# Altium schematic sheet HDMI.SchDoc(HDMI)
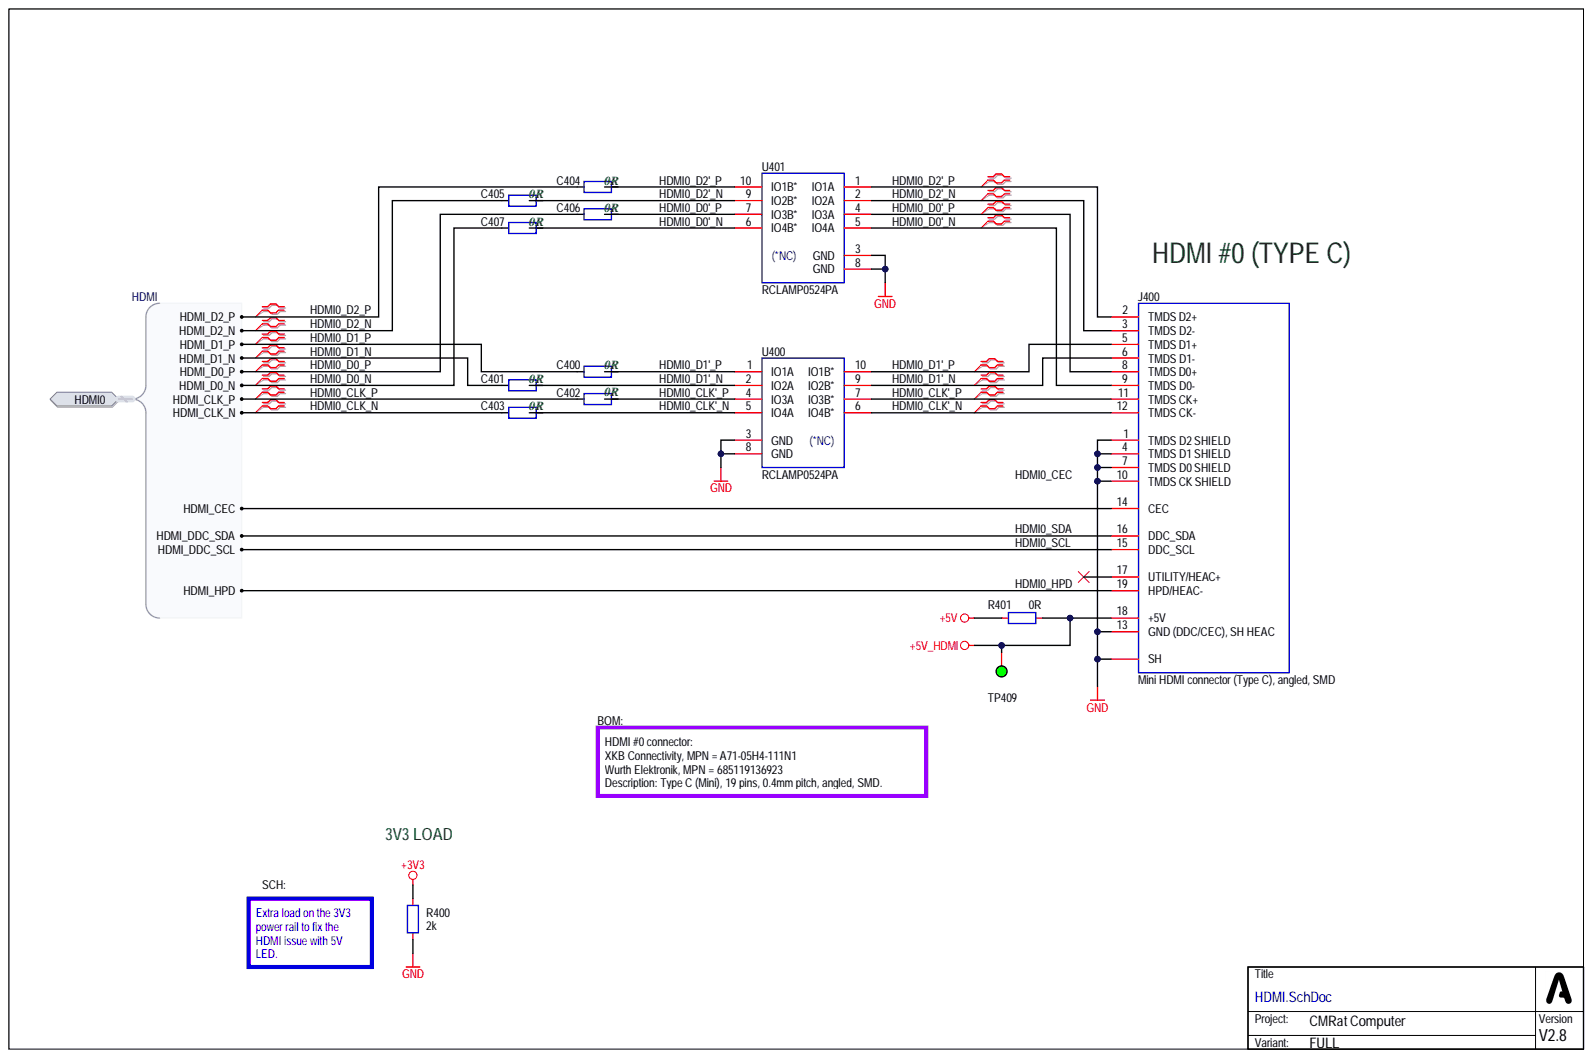
[diagram p0: HDMI.SchDoc]

## Parts
C400  0R  [RES_0402_R]
C400
C401  0R  [RES_0402_R]
C401
C402  0R  [RES_0402_R]
C402
C403  0R  [RES_0402_R]
C403
C404  0R  [RES_0402_R]
C404
C405  0R  [RES_0402_R]
C405
C406  0R  [RES_0402_R]
C406
C407  0R  [RES_0402_R]
C407
J400  Mini HDMI connector (Type C), angled, SMD  Mounting Type: SMD  [CON_XKB_A71-05H4-1X1N1]
R400  2k  [RES_0603_R]
R401  0R  [RES_0603_R]
TB400  =Value  (no BOM)
TP409  ICT  [PCB_TP_ICT_100]  (value not drawn)  (no BOM)
U400  RCLAMP0524PA  [ICC_SLP2510P8_250X100X60_P50_R]
U401  RCLAMP0524PA  [ICC_SLP2510P8_250X100X60_P50_R]

## Ports
HDMI0, HDMI0.HDMI_CEC, HDMI0.HDMI_CLK_N, HDMI0.HDMI_CLK_P, HDMI0.HDMI_D0_N, HDMI0.HDMI_D0_P, HDMI0.HDMI_D1_N, HDMI0.HDMI_D1_P, HDMI0.HDMI_D2_N, HDMI0.HDMI_D2_P, HDMI0.HDMI_DDC_SCL, HDMI0.HDMI_DDC_SDA, HDMI0.HDMI_HPD

## Nets
+3V3: R400.2
+5V: R401.2
+5V_HDMI: J400.18(+5V) R401.1 TP409.1
GND: J400.1(TMDS_D2_SHIELD) J400.4(TMDS_D1_SHIELD) J400.7(TMDS_D0_SHIELD) J400.10(TMDS_CK_SHIELD) J400.13(GND_(DDC/CEC),_SH_HEAC) J400.SH R400.1 U400.3(GND) U400.8(GND) U401.3(GND) U401.8(GND)
HDMI0: J400.14(CEC) ; port HDMI0
HDMI0_CLK'_N: C403.2 J400.12(TMDS_CK-) U400.5(IO4A) U400.6(IO4B*)
HDMI0_CLK'_P: C402.2 J400.11(TMDS_CK+) U400.4(IO3A) U400.7(IO3B*)
HDMI0_CLK_N: C403.1 ; port HDMI0
HDMI0_CLK_P: C402.1 ; port HDMI0
HDMI0_D0'_N: C407.2 J400.9(TMDS_D0-) U401.5(IO4A) U401.6(IO4B*)
HDMI0_D0'_P: C406.2 J400.8(TMDS_D0+) U401.4(IO3A) U401.7(IO3B*)
HDMI0_D0_N: C407.1 ; port HDMI0
HDMI0_D0_P: C406.1 ; port HDMI0
HDMI0_D1'_N: C401.2 J400.6(TMDS_D1-) U400.2(IO2A) U400.9(IO2B*)
HDMI0_D1'_P: C400.2 J400.5(TMDS_D1+) U400.1(IO1A) U400.10(IO1B*)
HDMI0_D1_N: C401.1 ; port HDMI0
HDMI0_D1_P: C400.1 ; port HDMI0
HDMI0_D2'_N: C405.2 J400.3(TMDS_D2-) U401.2(IO2A) U401.9(IO2B*)
HDMI0_D2'_P: C404.2 J400.2(TMDS_D2+) U401.1(IO1A) U401.10(IO1B*)
HDMI0_D2_N: C405.1 ; port HDMI0
HDMI0_D2_P: C404.1 ; port HDMI0
HDMI0_HPD: J400.19(HPD/HEAC-) ; port HDMI0
HDMI0_SCL: J400.15(DDC_SCL) ; port HDMI0
HDMI0_SDA: J400.16(DDC_SDA) ; port HDMI0
HDMI0_CEC:

Not connected: J400 17(UTILITY/HEAC+)

## Library data of the parts
C400-C407 = ITEM_R10045: RES SMD 0Ω ±1% 62.5mW ±800ppm (0402); Manufacturer: UNI-ROYAL(Uniroyal Elec); MPN: GRM155R61H224KE01D; Manufacturer Part Number: 0402WGF0000TCE; Component Subtype: MLCC; Database Table Name: RES$; Package (Case): 0402; Value: 220nF; Component Kind: Standard; Component Type: Capacitor; Library Name: DB_DBLIB.DbLib; Mounting Type: SMD; Case: 0402; ITEM: ITEM_R10045; JLC Description: 62.5mW Thick Film Resistors 50V ±800ppm/℃ ±1% 0Ω 0402 Chip Resistor - Surface Mount ROHS; JLCPCB Part #: C17168; Mounting: S; Power: 62.5mW; PPM: ±800ppm; Price: 0,0005; Resistance: 0Ω; Resistor Type: Thick Film Resistors; Stock: 0; Tolerance: ±1%
J400 = CON_HDMI_1.4+_MINI_TYPE_C_A1: Connector; HDMI v1.4+; Type C (Mini-HDMI);; Manufacturer: XKB Connectivity; MPN: A71-05H4-111N1; Component Subtype: Mini HDMI (Type C); Component Kind: Standard; Component Type: Connector; Package (Case): SMD; Value: Mini HDMI connector (Type C), angled, SMD; Library Name: Pineberry_Lib.SchLib
R400 = RES-0033: Resistor; Thick Film; 2k2; 1%; 100mW; 100PPM; 75V; Standard; SMD; 0603 (1608);; Manufacturer: UNI-ROYAL(Uniroyal Elec); MPN: 0603WAF2001T5E; Database Table Name: RESISTOR$; Package (Case): 0603; Component Kind: Standard; Component Subtype: Thick Film; Component Type: Resistor; Mounting Type: SMD; Value: 2k; Library Name: MirFol_db_local.DbLib
R401 = RES-0054: Resistor; Thick Film; 0R; SMD; 0603 (1608);; Manufacturer: UNI-ROYAL(Uniroyal Elec); MPN: 0603WAF0000T5E; Database Table Name: RESISTOR$; Package (Case): 0603; Component Kind: Standard; Component Subtype: Thick Film; Component Type: Resistor; Mounting Type: SMD; Value: 0R; Library Name: MirFol_db_local.DbLib
TB400 = SCH_TITLE_BLOCK_SIMPLE_NODATE: Library Name: Symbols_NO_BOM.SchLib
TP409 = PCB_TP_ICT: Test Point (ICT); Component Type: Testpoint (for ICT); Mounting Type: Non component; Value: ICT; Library Name: MirFol_lib.SchLib
U400 U401 = ICC-0005: IC; TVS Arrays; ESD Protection; 4-Channel; 17kV Air; 12kV Contact; 0.3pF Capitance; TBD; SMD; TBD;; Manufacturer: Semtech Corporation; MPN: RCLAMP0524PATCT; Database Table Name: IC (MISC)$; Package (Case): DFN-10(1x2.5); Component Kind: Standard; Component Subtype: TVS Arrays; Component Type: IC; Mounting Type: SMD; Value: RCLAMP0524PA; Library Name: MirFol_db_local.DbLib
